# Altium schematic sheet RF_Switch.SchDoc(RF_Switch)
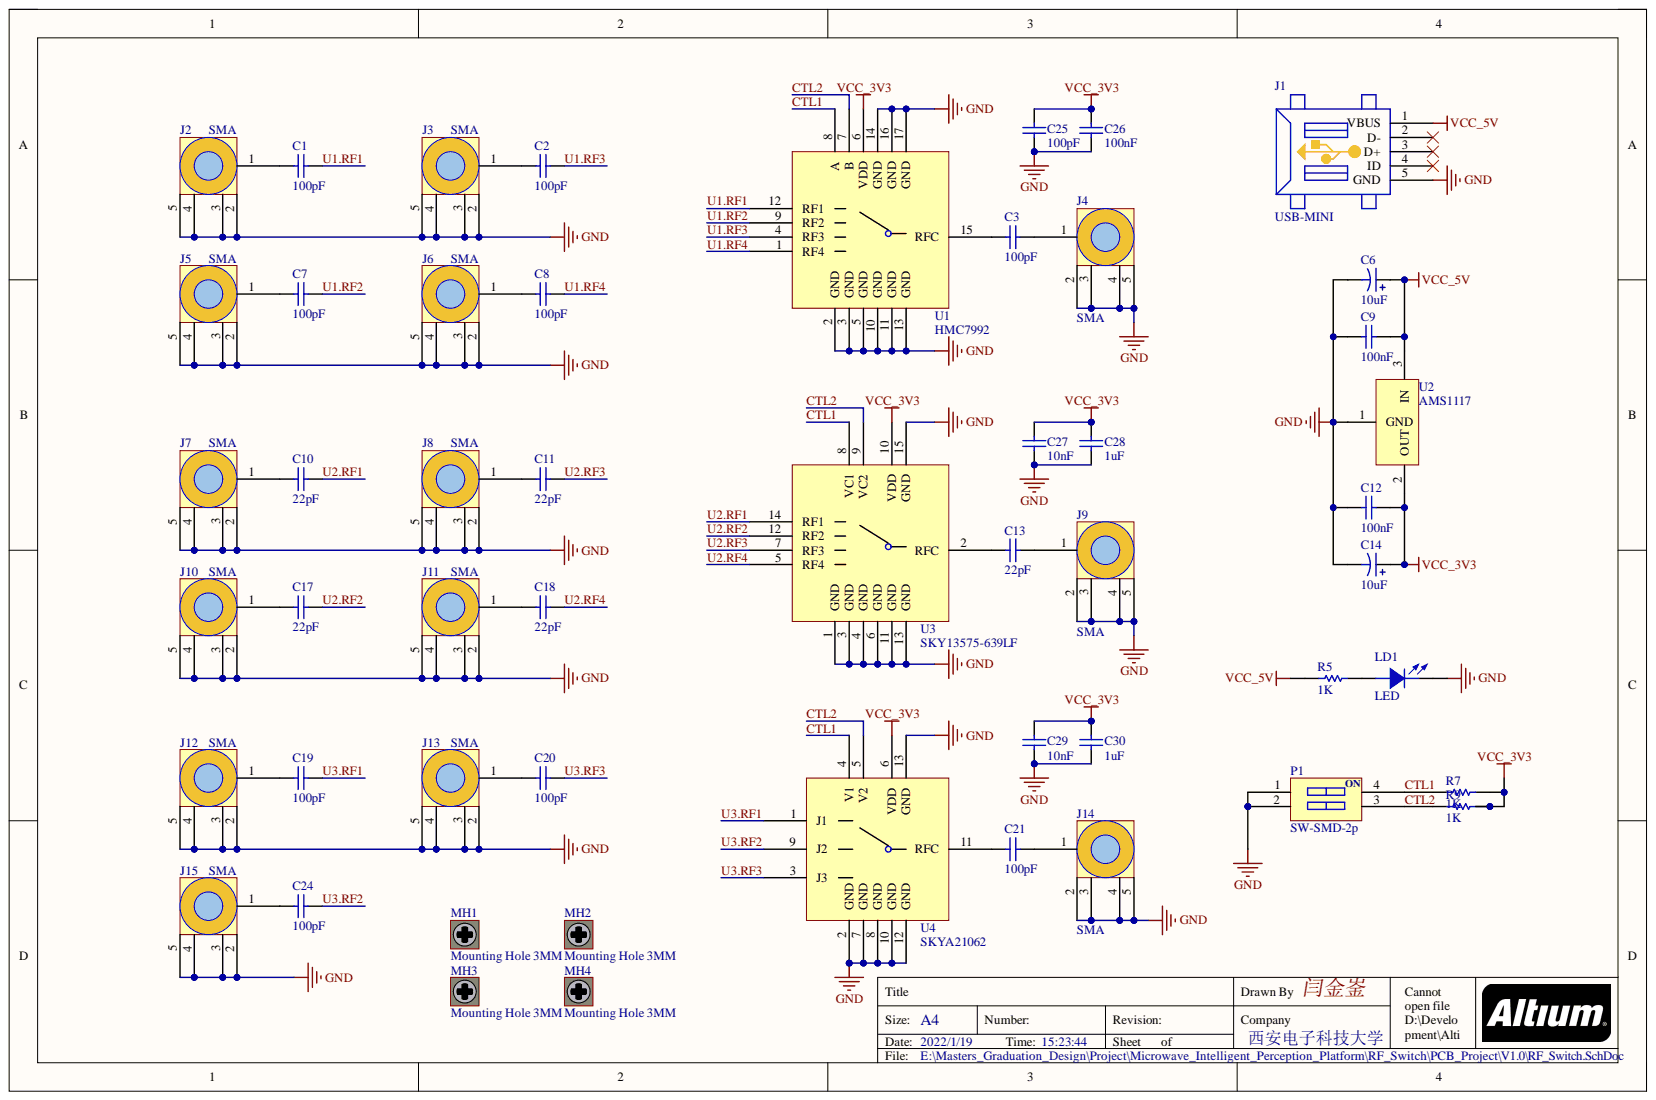
[diagram p0: RF_Switch.SchDoc]

## Parts
C1  100pF  [C-0603]
C2  100pF  [C-0603]
C3  100pF  [C-0603]
C6  10uF  [C-B3528]
C7  100pF  [C-0603]
C8  100pF  [C-0603]
C9  100nF  [C-0603]
C10  22pF  [C-0603]
C11  22pF  [C-0603]
C12  100nF  [C-0603]
C13  22pF  [C-0603]
C14  10uF  [C-B3528]
C17  22pF  [C-0603]
C18  22pF  [C-0603]
C19  100pF  [C-0603]
C20  100pF  [C-0603]
C21  100pF  [C-0603]
C24  100pF  [C-0603]
C25  100pF  [C-0603]
C26  100nF  [C-0603]
C27  10nF  [C-0603]
C28  1uF  [C-0603]
C29  10nF  [C-0603]
C30  1uF  [C-0603]
J1  USB-MINI  [USB-MICRO]
J2  SMA  [SMA-KHD]
J3  SMA  [SMA-KHD]
J4  SMA  [SMA-KHD]
J5  SMA  [SMA-KHD]
J6  SMA  [SMA-KHD]
J7  SMA  [SMA-KHD]
J8  SMA  [SMA-KHD]
J9  SMA  [SMA-KHD]
J10  SMA  [SMA-KHD]
J11  SMA  [SMA-KHD]
J12  SMA  [SMA-KHD]
J13  SMA  [SMA-KHD]
J14  SMA  [SMA-KHD]
J15  SMA  [SMA-KHD]
LD1  LED  [LED-WHITE-0603]
MH1  Mounting Hole 3MM  [MOUNT]
MH2  Mounting Hole 3MM  [MOUNT]
MH3  Mounting Hole 3MM  [MOUNT]
MH4  Mounting Hole 3MM  [MOUNT]
P1  SW-SMD-2p  [SW-2p-2.54mm]
R5  1K  [R-0603]
R7  1K  [R-0603]
R9  1K  [R-0603]
U1  HMC7992  [LFCSP16-3x3]
U2  AMS1117  [SOT-223]
U3  SKY13575-639LF  [QFN14-1.6x1.6]
U4  SKYA21062  [QFN12-2x2]

## Nets
GND: C6.2 C9.1 C12.1 C14.2 C25.1 C26.1 C27.1 C28.1 C29.1 C30.1 J1.5(GND) J2.2 J2.3 J2.4 J2.5 J3.2 J3.3 J3.4 J3.5 J4.2 J4.3 J4.4 J4.5 J5.2 J5.3 J5.4 J5.5 J6.2 J6.3 J6.4 J6.5 J7.2 J7.3 J7.4 J7.5 J8.2 J8.3 J8.4 J8.5 J9.2 J9.3 J9.4 J9.5 J10.2 J10.3 J10.4 J10.5 J11.2 J11.3 J11.4 J11.5 J12.2 J12.3 J12.4 J12.5 J13.2 J13.3 J13.4 J13.5 J14.2 J14.3 J14.4 J14.5 J15.2 J15.3 J15.4 J15.5 LD1.2 P1.1 P1.2 U1.2(GND) U1.3(GND) U1.5(GND) U1.10(GND) U1.11(GND) U1.13(GND) U1.14(GND) U1.16(GND) U1.17(GND) U2.1 U3.1(GND) U3.3(GND) U3.4(GND) U3.6(GND) U3.11(GND) U3.13(GND) U3.15(GND) U4.2(GND) U4.7(GND) U4.8(GND) U4.10(GND) U4.12(GND) U4.13(GND)
VCC_3V3: C12.2 C14.1 C25.2 C26.2 C27.2 C28.2 C29.2 C30.2 R7.1 R9.1 U1.6(VDD) U2.2 U3.10(VDD) U4.6(VDD)
VCC_5V: C6.1 C9.2 J1.1(VBUS) R5.1 U2.3
CTL1: P1.4 R7.2 U1.8(A) U3.8(VC1) U4.4(V1)
CTL2: P1.3 R9.2 U1.7(B) U3.9(VC2) U4.5(V2)
U1.RF1: C1.2 U1.12(RF1)
U1.RF2: C7.2 U1.9(RF2)
U1.RF3: C2.2 U1.4(RF3)
U1.RF4: C8.2 U1.1(RF4)
U2.RF1: C10.2 U3.14(RF1)
U2.RF2: C17.2 U3.12(RF2)
U2.RF3: C11.2 U3.7(RF3)
U2.RF4: C18.2 U3.5(RF4)
U3.RF1: C19.2 U4.1(J1)
U3.RF2: C24.2 U4.9(J2)
U3.RF3: C20.2 U4.3(J3)
NetC1_1: C1.1 J2.1
NetC2_1: C2.1 J3.1
NetC3_1: C3.1 U1.15(RFC)
NetC3_2: C3.2 J4.1
NetC7_1: C7.1 J5.1
NetC8_1: C8.1 J6.1
NetC10_1: C10.1 J7.1
NetC11_1: C11.1 J8.1
NetC13_1: C13.1 U3.2(RFC)
NetC13_2: C13.2 J9.1
NetC17_1: C17.1 J10.1
NetC18_1: C18.1 J11.1
NetC19_1: C19.1 J12.1
NetC20_1: C20.1 J13.1
NetC21_1: C21.1 U4.11(RFC)
NetC21_2: C21.2 J14.1
NetC24_1: C24.1 J15.1
NetLD1_1: LD1.1 R5.2

Not connected: J1 2(D-) 3(D+) 4(ID)

## Library data of the parts
C1-C3 C7 C8 C10 C11 C13 C17-C21 C24 C25 C27-C30 = CAP: Capacitor; Value: 100nF; Component Kind: Standard; LatestRevisionDate: 17-Jul-2002; LatestRevisionNote: Re-released for DXP Platform.; PackageDescription: Radial Cap, Thru-Hole; 2 Leads; 0.3 in Pin Spacing; PackageReference: RAD-0.3; Published: 8-Jun-2000; Library Name: Verdvana_Library.IntLib; Publisher: Altium Limited
C6 C14 = CAP2: Capacitor; Component Kind: Standard; PackageDescription: Capacitor; 2 Leads; PackageReference: CAPR5-4X5; Published: 23-Sep-2002; Value: 10uF; Library Name: Wireless_Sensing_test.SchLib; Publisher: Altium Limited
C9 C12 C26 = CAP: Capacitor; Component Kind: Standard; LatestRevisionDate: 17-Jul-2002; LatestRevisionNote: Re-released for DXP Platform.; PackageDescription: Radial Cap, Thru-Hole; 2 Leads; 0.3 in Pin Spacing; PackageReference: RAD-0.3; Published: 8-Jun-2000; Value: 100nF; Publisher: Altium Limited
  C9 C12: Library Name: Wireless_Sensing_test.SchLib
  C26: Library Name: Verdvana_Library.IntLib
J1 = USB-MINI: USB-MINI/USB-Micro母座; Component Kind: Standard; Library Name: Wireless_Sensing_test.SchLib
P1 = SW-DIP-2p: Component Kind: Standard; Description: SW-DIP-2p; Library Name: Wireless_Sensing.SchLib
R5 R7 R9 = RES: Potentiometer; Set Position: 0.5; Sim Note: Enter the Resistance value and the set point into parameter fields.; Component Kind: Standard; LatestRevisionDate: 17-Jul-2002; LatestRevisionNote: Re-released for DXP Platform.; PackageDescription: Variable Resistor, Thru-Hole; 3 Leads; PackageReference: VR5; Published: 8-Jun-2000; Value: 1K; Publisher: Altium Limited
  R5: Library Name: Wireless_Sensing_test.SchLib
  R7 R9: Library Name: CSI_Board.SchLib
U1 = HMC7992: 0.1 GHz to 6.0 GHz Silicon SP4T Switch; Component Kind: Standard; Library Name: Verdvana_Library.IntLib
U3 = SKY13575-639LF: Dual-Band Matched SP4T Wi-Fi Switch; Component Kind: Standard; Library Name: Verdvana_Library.IntLib
U4 = SKYA21062: 0.1 to 6.0 GHz SP3T Switch; Component Kind: Standard; Library Name: Verdvana_Library.IntLib
J2-J15 = SMA: Component Kind: Standard; Description: SMA; Library Name: CSI_Board.SchLib
LD1 = LED: Component Kind: Standard; Description: LED; Library Name: Wireless_Sensing_test.SchLib
MH1-MH4 = Mounting Hole 3MM: Component Kind: Standard; Description: Mounting Hole 3MM; Library Name: Wireless_Sensing_test.SchLib
U2 = AMS1117: Component Kind: Standard; Library Name: Wireless_Sensing_test.SchLib
